# Altium schematic sheet jsk_mbzirc_micro2.SchDoc(jsk_mbzirc_micro2)
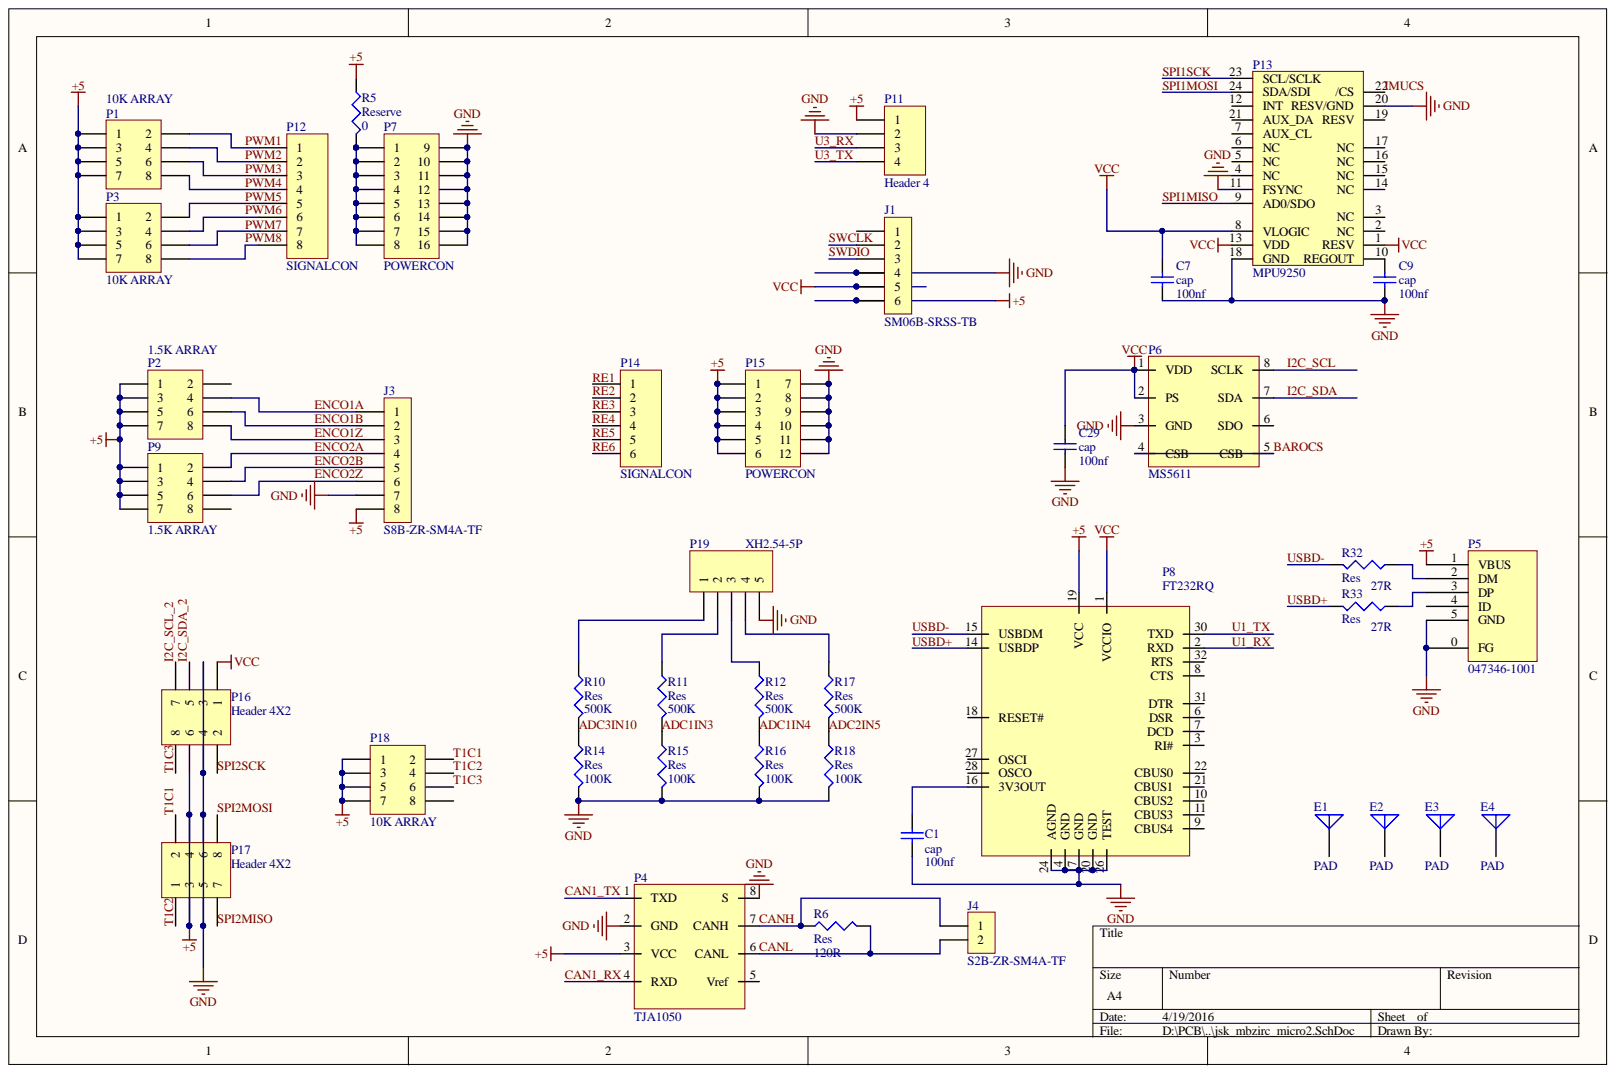
[diagram p0: jsk_mbzirc_micro2.SchDoc]

## Parts
C1  cap  value 100nf  [J1-0603]
C7  cap  value 100nf  [J1-0603]
C9  cap  value 100nf  [J1-0603]
C29  cap  value 100nf  [J1-0603]
E1  PAD  [pad3]
E2  PAD  [pad3]
E3  PAD  [pad3]
E4  PAD  [pad3]
J1  SM06B-SRSS-TB  [SM06B-SRSS-TB]
J3  S8B-ZR-SM4A-TF  [S8B-ZR-SM4A-TF]
J4  S2B-ZR-SM4A-TF  [S2B-ZR-SM4A-TF]
P1  10K ARRAY  [res4p]
P2  1.5K ARRAY  [res4p]
P3  10K ARRAY  [res4p]
P4  TJA1050  [so-8]
P5  047346-1001  [047346-1001]
P6  MS5611  [barom]
P7  POWERCON  [HDR2X8_CEN]
P8  FT232RQ  [QFN32]
P9  1.5K ARRAY  [res4p]
P11  Header 4  [HDR1X4]
P12  SIGNALCON  [HDR1X8]
P13  MPU9250  [MPU9250]
P14  SIGNALCON  [HDR1X6]
P15  POWERCON  [HDR2X6_CEN]
P16  Header 4X2  [HDR2X4]
P17  Header 4X2  [HDR2X4]
P18  10K ARRAY  [res4p]
P19  XH2.54-5P  [HDR1X5]
R5  Reserve  value 0  [J1-0603]
R6  Res  value 120R  [J1-0603]
R10  Res  value 500K  [J1-0603]
R11  Res  value 500K  [J1-0603]
R12  Res  value 500K  [J1-0603]
R14  Res  value 100K  [J1-0603]
R15  Res  value 100K  [J1-0603]
R16  Res  value 100K  [J1-0603]
R17  Res  value 500K  [J1-0603]
R18  Res  value 100K  [J1-0603]
R32  Res  value 27R  [J1-0603]
R33  Res  value 27R  [J1-0603]

## Nets
+5: J1.6 J3.8 P1.1 P1.3 P1.5 P1.7 P2.1 P2.3 P2.5 P2.7 P3.1 P3.3 P3.5 P3.7 P4.3(VCC) P5.1(VBUS) P8.19(VCC) P9.1 P9.3 P9.5 P9.7 P11.1 P15.1 P15.2 P15.3 P15.4 P15.5 P15.6 P16.6 P17.3 P17.4 P18.1 P18.3 P18.5 P18.7 R5.1
GND: C1.1 C7.1 C9.1 C29.1 J1.4 J3.7 P4.2(GND) P4.8(S) P5.0(FG) P5.5(GND) P6.3(GND) P7.9 P7.10 P7.11 P7.12 P7.13 P7.14 P7.15 P7.16 P8.4(GND) P8.17(GND) P8.20(GND) P8.24(AGND) P8.26(TEST) P11.2 P13.11(FSYNC) P13.18(GND) P13.20(RESV/GND) P15.7 P15.8 P15.9 P15.10 P15.11 P15.12 P16.3 P16.4 P17.5 P17.6 P19.5 R14.2 R15.2 R16.2 R18.2
VCC: C7.2 C29.2 J1.5 P6.1(VDD) P6.2(PS) P8.1(VCCIO) P13.1(RESV) P13.8(VLOGIC) P13.13(VDD) P16.1
ADC1IN3: R11.2 R15.1
ADC1IN4: R12.2 R16.1
ADC2IN5: R17.2 R18.1
ADC3IN10: R10.2 R14.1
BAROCS: P6.4(CSB) P6.5(CSB)
CAN1_RX: P4.4(RXD)
CAN1_TX: P4.1(TXD)
CANH: J4.1 P4.7(CANH) R6.1
CANL: J4.2 P4.6(CANL) R6.2
ENCO1A: J3.1 P2.4
ENCO1B: J3.2 P2.6
ENCO1Z: J3.3 P2.8
ENCO2A: J3.4 P9.2
ENCO2B: J3.5 P9.4
ENCO2Z: J3.6 P9.6
I2C_SCL: P6.8(SCLK)
I2C_SCL_2: P16.7
I2C_SDA: P6.7(SDA)
I2C_SDA_2: P16.5
IMUCS: P13.22(/CS)
PWM1: P1.2 P12.1
PWM2: P1.4 P12.2
PWM3: P1.6 P12.3
PWM4: P1.8 P12.4
PWM5: P3.2 P12.5
PWM6: P3.4 P12.6
PWM7: P3.6 P12.7
PWM8: P3.8 P12.8
RE1: P14.1
RE2: P14.2
RE3: P14.3
RE4: P14.4
RE5: P14.5
RE6: P14.6
SPI1MISO: P13.9(AD0/SDO)
SPI1MOSI: P13.24(SDA/SDI)
SPI1SCK: P13.23(SCL/SCLK)
SPI2MISO: P17.7
SPI2MOSI: P17.8
SPI2SCK: P16.2
SWCLK: J1.2
SWDIO: J1.3
T1C1: P17.2 P18.2
T1C2: P17.1 P18.4
T1C3: P16.8 P18.6
U1_RX: P8.2(RXD)
U1_TX: P8.30(TXD)
U3_RX: P11.3
U3_TX: P11.4
USBD+: P8.14(USBDP) R33.2
USBD-: P8.15(USBDM) R32.2
NetC1_2: C1.2 P8.16(3V3OUT)
NetC9_2: C9.2 P13.10(REGOUT)
NetP5_2: P5.2(DM) R32.1
NetP5_3: P5.3(DP) R33.1
NetP7_1: P7.1 P7.2 P7.3 P7.4 P7.5 P7.6 P7.7 P7.8 R5.2
NetP19_1: P19.1 R10.1
NetP19_2: P19.2 R11.1
NetP19_3: P19.3 R12.1
NetP19_4: P19.4 R17.1

Not connected: E1 1; E2 1; E3 1; E4 1; J1 1; P2 2; P4 5(Vref); P5 4(ID); P6 6(SDO); P8 3(RI#) 6(DSR) 7(DCD) 8(CTS) 9(CBUS4) 10(CBUS2) 11(CBUS3) 18(RESET#) 21(CBUS1) 22(CBUS0) 27(OSCI) 28(OSCO) 31(DTR) 32(RTS); P9 8; P13 2(NC) 3(NC) 4(NC) 5(NC) 6(NC) 7(AUX_CL) 12(INT) 14(NC) 15(NC) 16(NC) 17(NC) 19(RESV) 21(AUX_DA); P18 8

## Library data of the parts
C1 C7 C9 C29 = Cap Semi: Capacitor (Semiconductor SIM Model); Component Kind: Standard; LatestRevisionDate: 29-May-2009; LatestRevisionNote: IPC-7351 Footprint Added.; Library Name: Miscellaneous Devices.IntLib; PackageDescription: Chip Capacitor; PackageReference: C1206; Published: 8-Jun-2000; Signal Integrity: Cap Semi; Simulation: CAP; Publisher: Altium Limited
E1-E4 = Antenna: Generic Antenna; Component Kind: Standard; LatestRevisionDate: 17-Jul-2002; LatestRevisionNote: Re-released for DXP Platform.; Library Name: Miscellaneous Devices.IntLib; PackageReference: PIN1; Published: 24-Mar-1999; Publisher: Altium Limited
J1 = SM06B-SRSS-TB: Connector; Manufacturer: JST; Assembly: P; Component Kind: Standard; Library Name: JST_SH.IntLib; PN: SM06B-SRSS-TB; Supplier: JST
J3 = S8B-ZR-SM4A-TF: Connector; Manufacturer: JST; Assembly: P; Component Kind: Standard; PN: S8B-ZR-SM4A-TF; Supplier: JST; Library Name: JST_ZH.IntLib
J4 = S2B-ZR-SM4A-TF: Connector; Manufacturer: JST; Assembly: P; Component Kind: Standard; PN: S2B-ZR-SM4A-TF; Supplier: JST; Library Name: JST_ZH.IntLib
P1-P3 P9 P16-P18 = Header 4X2: Header, 4-Pin, Dual row; Component Kind: Standard; LatestRevisionDate: 17-Jul-2002; LatestRevisionNote: Re-released for DXP Platform.; Library Name: Miscellaneous Connectors.IntLib; Publisher: Altium Limited
  P16 P17: Signal Integrity: Connector
P5 = 047346-1001: Micro USB B Connector; Manufacturer: Molex; Assembly: P; Component Kind: Standard; Library Name: Molex.IntLib; PN: 047346-1001
P7 = Header 8X2A: Header, 8-Pin, Dual row; Component Kind: Standard; LatestRevisionDate: 17-Jul-2002; LatestRevisionNote: Re-released for DXP Platform.; Library Name: Miscellaneous Connectors.IntLib; Signal Integrity: Connector; Publisher: Altium Limited
P11 = Header 4: Header, 4-Pin; Component Kind: Standard; LatestRevisionDate: 17-Jul-2002; LatestRevisionNote: Re-released for DXP Platform.; Library Name: Miscellaneous Connectors.IntLib; Signal Integrity: Connector; Publisher: Altium Limited
P12 = Header 8: Header, 8-Pin; Component Kind: Standard; LatestRevisionDate: 17-Jul-2002; LatestRevisionNote: Re-released for DXP Platform.; Library Name: Miscellaneous Connectors.IntLib; Signal Integrity: Connector; Publisher: Altium Limited
P14 = Header 6: Header, 6-Pin; Component Kind: Standard; LatestRevisionDate: 17-Jul-2002; LatestRevisionNote: Re-released for DXP Platform.; Library Name: Miscellaneous Connectors.IntLib; Signal Integrity: Connector; Publisher: Altium Limited
P15 = Header 6X2A: Header, 6-Pin, Dual row; Component Kind: Standard; LatestRevisionDate: 17-Jul-2002; LatestRevisionNote: Re-released for DXP Platform.; Library Name: Miscellaneous Connectors.IntLib; Signal Integrity: Connector; Publisher: Altium Limited
P19 = Header 5: Header, 5-Pin; Component Kind: Standard; LatestRevisionDate: 17-Jul-2002; LatestRevisionNote: Re-released for DXP Platform.; Library Name: Miscellaneous Connectors.IntLib; Signal Integrity: Connector; Publisher: Altium Limited
R5 R6 R10-R12 R14-R18 R32 R33 = Res3: Resistor; Component Kind: Standard; LatestRevisionDate: 29-May-2009; LatestRevisionNote: IPC-7351 Footprint Added.; Library Name: Miscellaneous Devices.IntLib; PackageDescription: Chip Resistor; PackageReference: J1-0603; Published: 8-Jun-2000; Signal Integrity: Res; Simulation: RESISTOR; Publisher: Altium Limited
P4 = TJA1050: Component Kind: Standard; Library Name: jskschemlib.SCHLIB
P6 = MS5611: Component Kind: Standard; Library Name: jskschemlib.SCHLIB
P8 = FT232RQ: Component Kind: Standard; Library Name: jskschemlib.SCHLIB
P13 = MPU9250: Component Kind: Standard; Library Name: jskschemlib.SCHLIB
